# Altium schematic sheet balbo_arduino_shield.SchDoc(balbo_arduino_shield)
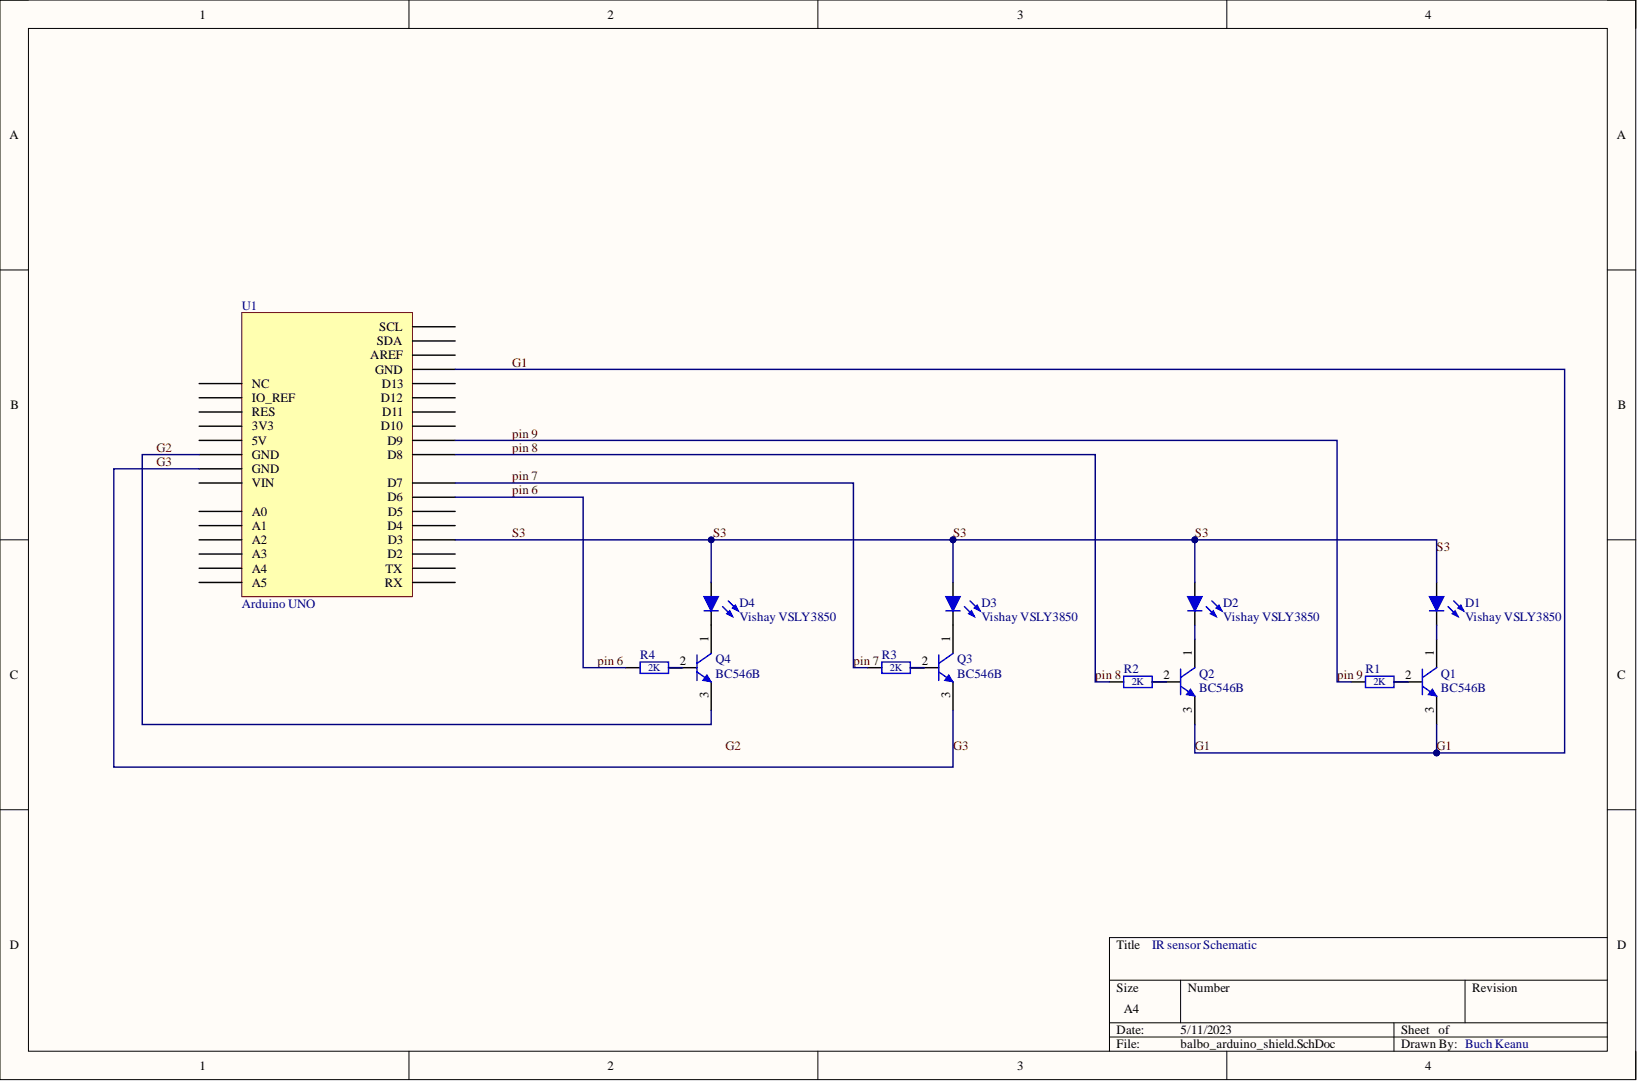
[diagram p0: balbo_arduino_shield.SchDoc]

## Parts
D1  Vishay VSLY3850  [PCB-s4y8w6zcj2my1lgy6jv2-1]
D2  Vishay VSLY3850  [PCB-s4y8w6zcj2my1lgy6jv2-1]
D3  Vishay VSLY3850  [PCB-s4y8w6zcj2my1lgy6jv2-1]
D4  Vishay VSLY3850  [PCB-s4y8w6zcj2my1lgy6jv2-1]
Q1  BC546B
Q2  BC546B
Q3  BC546B
Q4  BC546B
R1  (no value)  [PCB-84ygd7oc3od721r13ok9-1]
R2  (no value)  [PCB-84ygd7oc3od721r13ok9-1]
R3  (no value)  [PCB-84ygd7oc3od721r13ok9-1]
R4  (no value)  [PCB-84ygd7oc3od721r13ok9-1]
U1  Arduino UNO  [PCB-q4pvn1zbqrbs7w2g4x4d-1]

## Nets
G1: Q1.3 Q2.3 U1.GND
G2: Q4.3 U1.GND
G3: Q3.3 U1.GND
pin 6: R4.1 U1.D6
pin 7: R3.1 U1.D7
pin 8: R2.1 U1.D8
pin 9: R1.1 U1.D9
S3: D1.1 D2.1 D3.1 D4.1 U1.D3
NetD1_2: D1.2 Q1.1
NetD2_2: D2.2 Q2.1
NetD3_2: D3.2 Q3.1
NetD4_2: D4.2 Q4.1
NetQ1_2: Q1.2 R1.2
NetQ2_2: Q2.2 R2.2
NetQ3_2: Q3.2 R3.2
NetQ4_2: Q4.2 R4.2

Not connected: U1 3V3 5V A0 A1 A2 A3 A4 A5 AREF D2 D4 D5 D10 D11 D12 D13 IO_REF NC RES RX SCL SDA tX(TX) VIN

## Library data of the parts
D1-D4 = CMP-f7643cc9bb4428a3-6: Infrared Emitter 850nm 70mW/sr Circular Top Mount 2-Pin T-1 Bulk; Component Kind: Standard; Library Name: My Personal Space
Q1-Q4 = CMP-862221-2: Amplifier Transistor, NPN Silicon, 3-Pin TO-92, Bulk Box; Current Rating: 0.1 A; Lead-Free Status: Contains Lead; Voltage Rating (DC): 65.0 V; Case/Package: TO-92; Component Kind: Standard; Library Name: My Personal Space; Lifecycle Status: Not Listed by Manufacturer; Manufacturer Part Number: BC546B; Mounting Style: Through Hole; Octopart Url: https://octopart.com/bc546b-on+semiconductor-354085; OctopartID: 491780180b5496d0; Packaging: Bulk; RoHS: Compliant
R1-R3 = CMP-6215ab221019bdd2-1: Res Metal Film 2K Ohm 1% 0.25W(1/4W) ±100ppm/C Conformal Coated AXL Automotive T/R; Manufacturer Part Number: MFR-25FRF52-2K; Lead-Free Status: Lead Free; Power Rating: 250 mW; Resistance: 2.00 kΩ; Voltage Rating (DC): 200 V; Component Kind: Standard; Library Name: My Personal Space; Lifecycle Status: Not Listed by Manufacturer; Mounting Style: Through Hole; Octopart URL: http://octopart.com/mfr-25frf52-2k-yageo-4020074; OctopartID: 6215ab221019bdd2; Packaging: Reel; RoHS: Compliant
R4 = CMP-6215ab221019bdd2-1: Res Metal Film 2K Ohm 1% 0.25W(1/4W) ±100ppm/C Conformal Coated AXL Automotive T/R; Manufacturer Part Number: MFR-25FRF52-2K; Lead-Free Status: Lead Free; Power Rating: 250 mW; Resistance: 20.00 kΩ; Voltage Rating (DC): 200 V; Component Kind: Standard; Library Name: My Personal Space; Lifecycle Status: Not Listed by Manufacturer; Mounting Style: Through Hole; Octopart URL: http://octopart.com/mfr-25frf52-2k-yageo-4020074; OctopartID: 6215ab221019bdd2; Packaging: Reel; RoHS: Compliant
U1 = CMP-cdf305b1a0451234-27: Arduino Proto Shield Rev3 assembled; Manufacturer Part Number: A000077; Component Kind: Standard; Library Name: My Personal Space; Octopart URL: http://octopart.com/a000077-arduino-21514448; OctopartID: cdf305b1a0451234; REACH SVHC Compliance: No SVHC; RoHS: Compliant
